# Altium schematic sheet RA_64pin_Local_Promotion_Board_3.SchDoc(RA_64pin_Local_Promotion_Board_3)
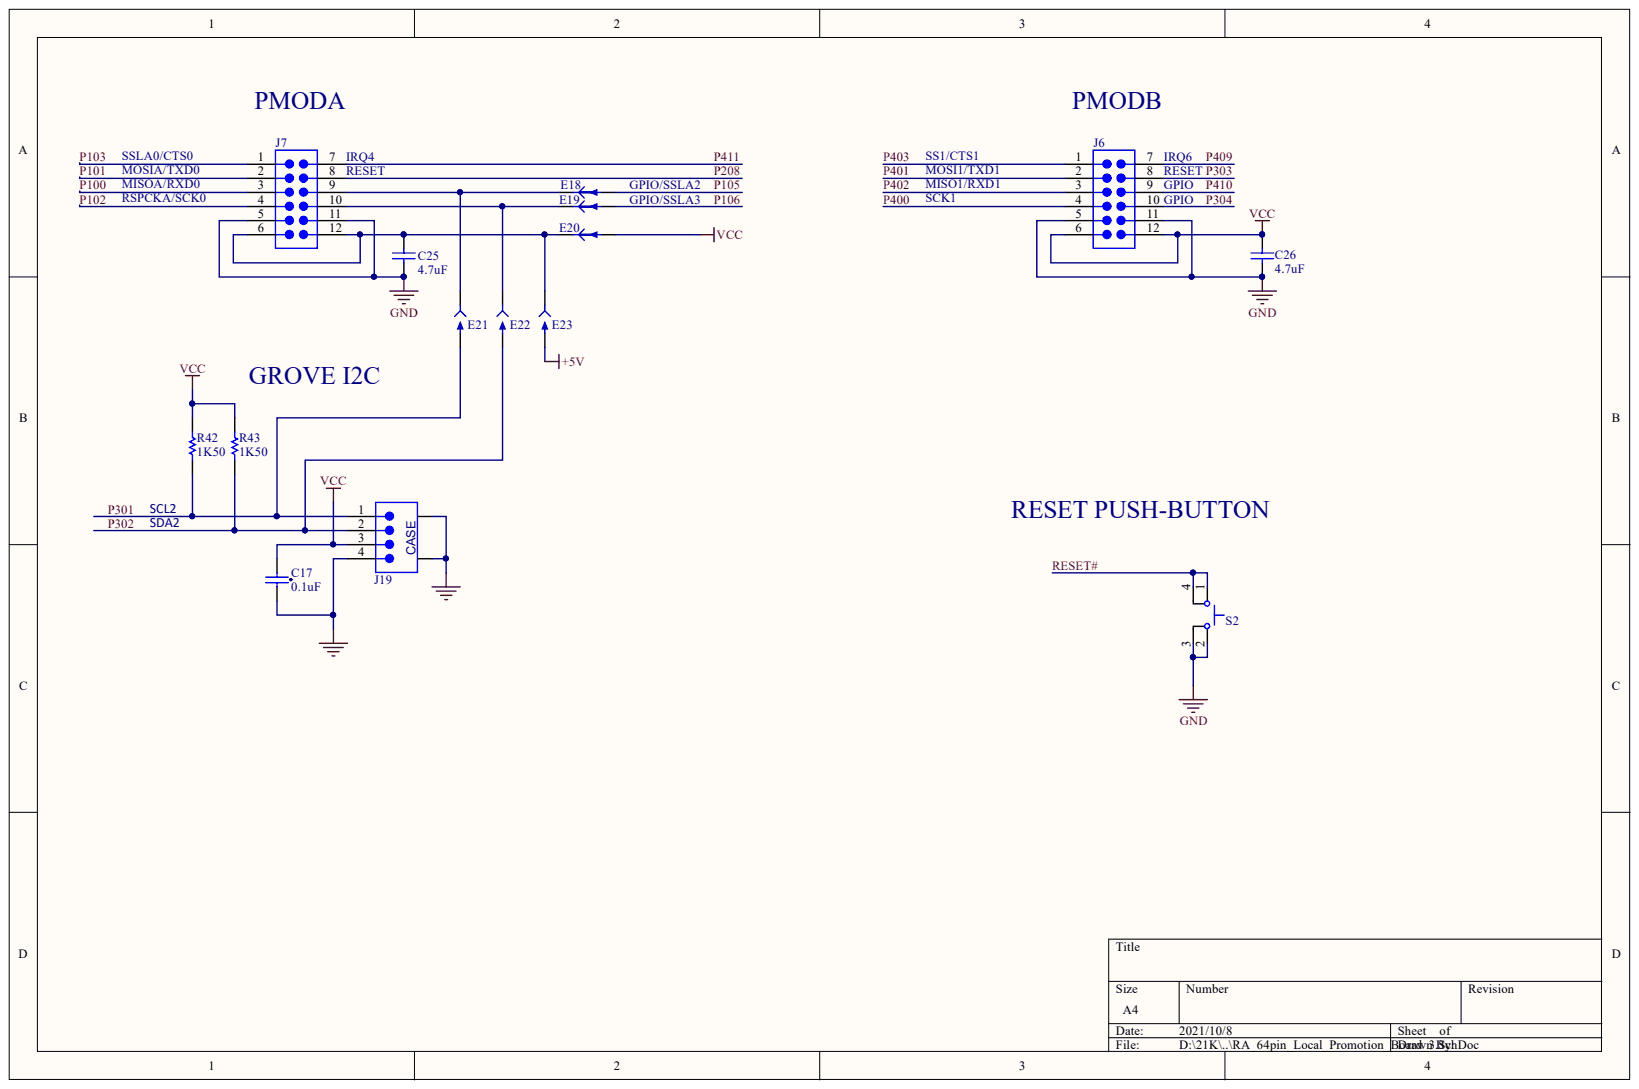
[diagram p0: RA_64pin_Local_Promotion_Board_3.SchDoc]

## Parts
C17  0.1uF  [CAPC0603-01]
C25  4.7uF  [CAPC0603-01]
C26  4.7uF  [CAPC0603-01]
E18  Jumper Trace Cut  [E_PadsTraceCut0805]  (value not drawn)  (no BOM)
E19  Jumper Trace Cut  [E_PadsTraceCut0805]  (value not drawn)  (no BOM)
E20  Jumper Trace Cut  [E_PadsTraceCut0805]  (value not drawn)  (no BOM)
E21  Jumper Solder Bridging  [E_PadsSplit0805]  (value not drawn)  (no BOM)
E22  Jumper Solder Bridging  [E_PadsSplit0805]  (value not drawn)  (no BOM)
E23  Jumper Solder Bridging  [E_PadsSplit0805]  (value not drawn)  (no BOM)
J6  PMOD  [SULLINS_PPTC062LFBN-RC-PMOD]  (value not drawn)
J7  PMOD  [SULLINS_PPTC062LFBN-RC-PMOD]  (value not drawn)
J19  SEEED Grove RA  [PA2.0_4P]  (value not drawn)
R42  1K50  [RESC0603-01]
R43  1K50  [RESC0603-01]
S2  Switch 4.5x4.5mm  [KEY A (4.5 X 4.5)]  (value not drawn)

## Nets
+5V: E23.2
GND: C17.2 C25.1 C26.1 J6.5 J6.11 J7.5 J7.11 J19.4 J19.PAD0 J19.PAD1 S2.2 S2.3
VCC: C17.1 C26.2 E20.2 J6.6 J6.12 J19.3 R42.2 R43.2
P100: J7.3
P101: J7.2
P102: J7.4
P103: J7.1
P105: E18.2
P106: E19.2
P208: J7.8
P301: E21.2 J19.1 R42.1
P302: E22.2 J19.2 R43.1
P303: J6.8
P304: J6.10
P400: J6.4
P401: J6.2
P402: J6.3
P403: J6.1
P409: J6.7
P410: J6.9
P411: J7.7
RESET#: S2.1 S2.4
NetC25_2: C25.2 E20.1 E23.1 J7.6 J7.12
NetE18_1: E18.1 E21.1 J7.9
NetE19_1: E19.1 E22.1 J7.10

## Library data of the parts
C17 = CAP-000030-02: Ceramic Chip Capacitor 0402 0.1uF 16V - vertical; Manufacturer: AVX; Manufacturer Part Number 1: 0402YC104KAT2A; Dialectric: X7R; Tolerance: 10%; Capacitance: 0.1uF; ClassName: GroveA; Component Kind: Standard; Library Name: Capacitors.IntLib; Manufacturer 1: AVX; Manufacturer 2: Kemet; Manufacturer Part Number 2: C0402C104K4RACTU; Mfg Part Number: 0402YC104KAT2A; Package: 0402; Supplier 1: Digi-Key; Supplier 2: Digi-Key; Supplier Part Number 1: 478-7888-1-ND; Supplier Part Number 2: 399-3521-2-ND; Voltage: 16V
C25 C26 = CAP-0603-4.7uF: Ceramic Chip Capacitor 0603 4.7uF 6.3V; Manufacturer: TDK; Mfg Part Number: CGA3E1X5R0J475K080AC; Dialectric: X5R; Tolerance: 10%; Capacitance: 4.7uF; Component Kind: Standard; Package: 0603; Voltage: 6.3V; Library Name: Synergy.SchLib
E18-E20 = E_JmpTraceCut: Pads on PCB with Trace Between for Cutting to isolate; Part Type: Jumper Trace Cut; Library Name: Synergy.SchLib
E21-E23 = E_JmpSldrBridge: Pads on PCB with Narrow Gap to allow Solder Bridging; Part Type: Jumper Solder Bridging; Library Name: Synergy.SchLib
J6 J7 = CON-2.54mm 2x6 Female: Female Header 0.1" pitch 2x6 Female; Manufacturer: Sullins; Mfg Part Number: PPTC062LFBN-RC; Pitch: 2.54mm; Positions: 12; Component Kind: Standard; Library Name: Synergy.SchLib
J19 = CON-100003-01: SEEED Right Angle SMT 2mm Grove System 4-pin; Manufacturer: NSTECH; Manufacturer Part Number 1: #NAME?; Mfg Part Number: 1125R-SMT-4P; Positions: 4; system: connector; ClassName: GroveA; Component Kind: Standard; Library Name: Connectors.IntLib; Manufacturer 1: NSTECH; Pitch: 2mm
R42 R43 = RES-000140-01: Chip Resistor Thick Film 0402 1K50 0.5% 1/16W; Manufacturer: Panasonic; Manufacturer Part Number 1: #NAME?; Mfg Part Number: ERA-2AED152X; ClassName: GroveA; Component Kind: Standard; Library Name: Resistors.IntLib; Manufacturer 1: Panasonic; Package: 0402; Power: 1/16W; Resistance: 1K50; Supplier 1: Digi-Key; Supplier Part Number 1: P1.5KDECT-ND; Tolerance: 0.5%
S2 = SWT-4.5x4.5mm: Push Button Switch 4.5 x 4.5mm 3.8mm High; Manufacturer: C&K; Mfg Part Number: PTS647SM38SMTR2 LFS; Component Kind: Standard; Library Name: New Com.SchLib
